# Altium schematic sheet RN487x Test Fixture v1.0.SchDoc
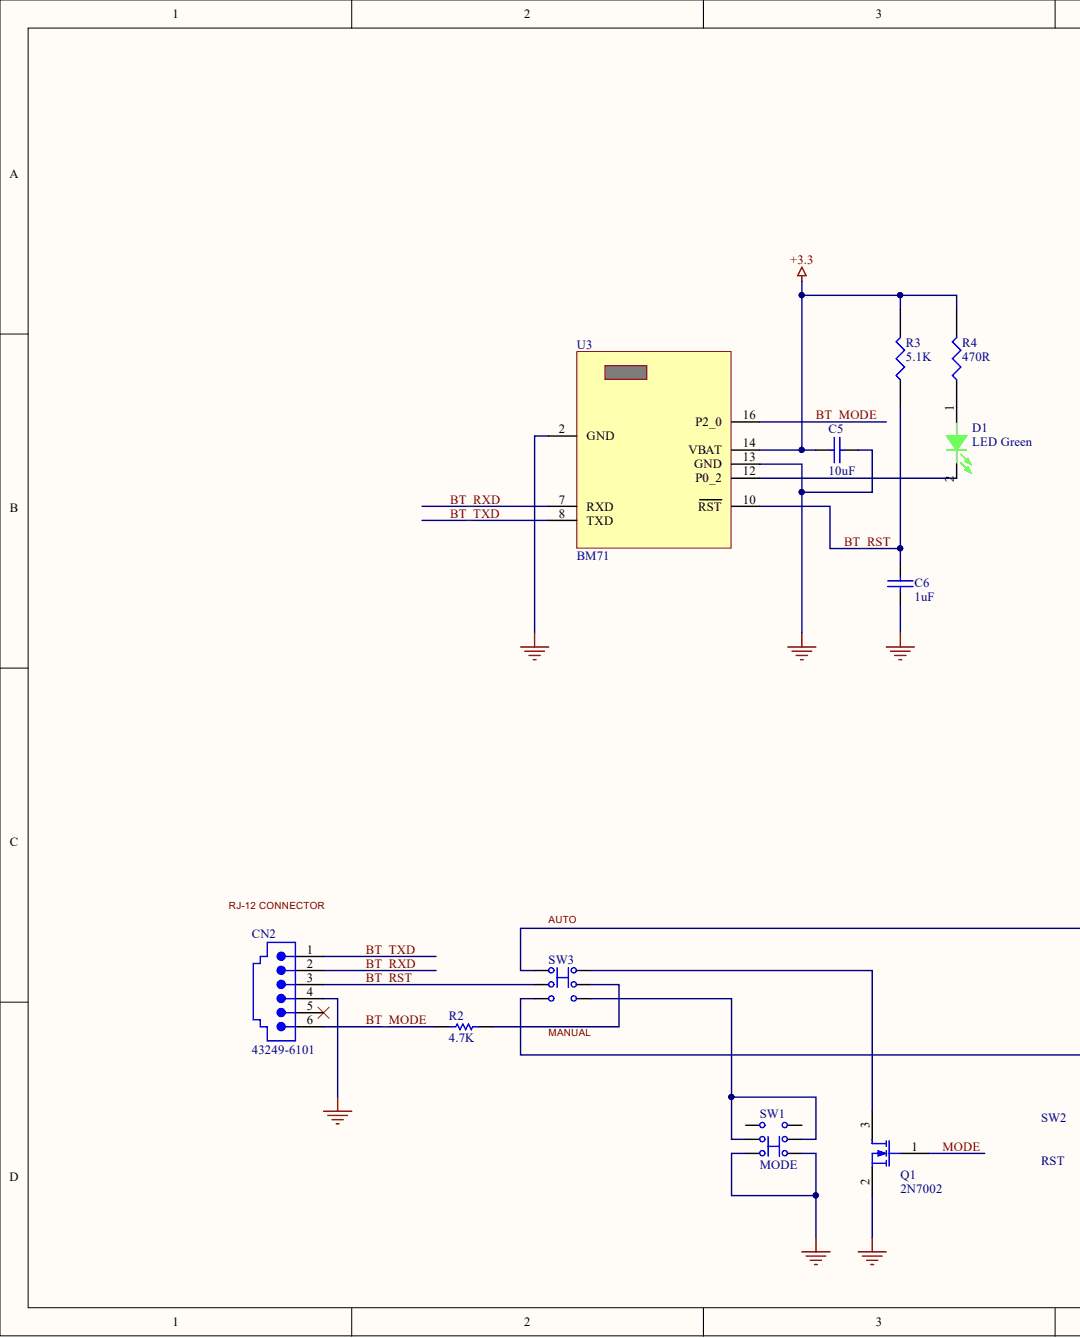
[diagram p0: RN487x Test Fixture v1.0.SchDoc, left]
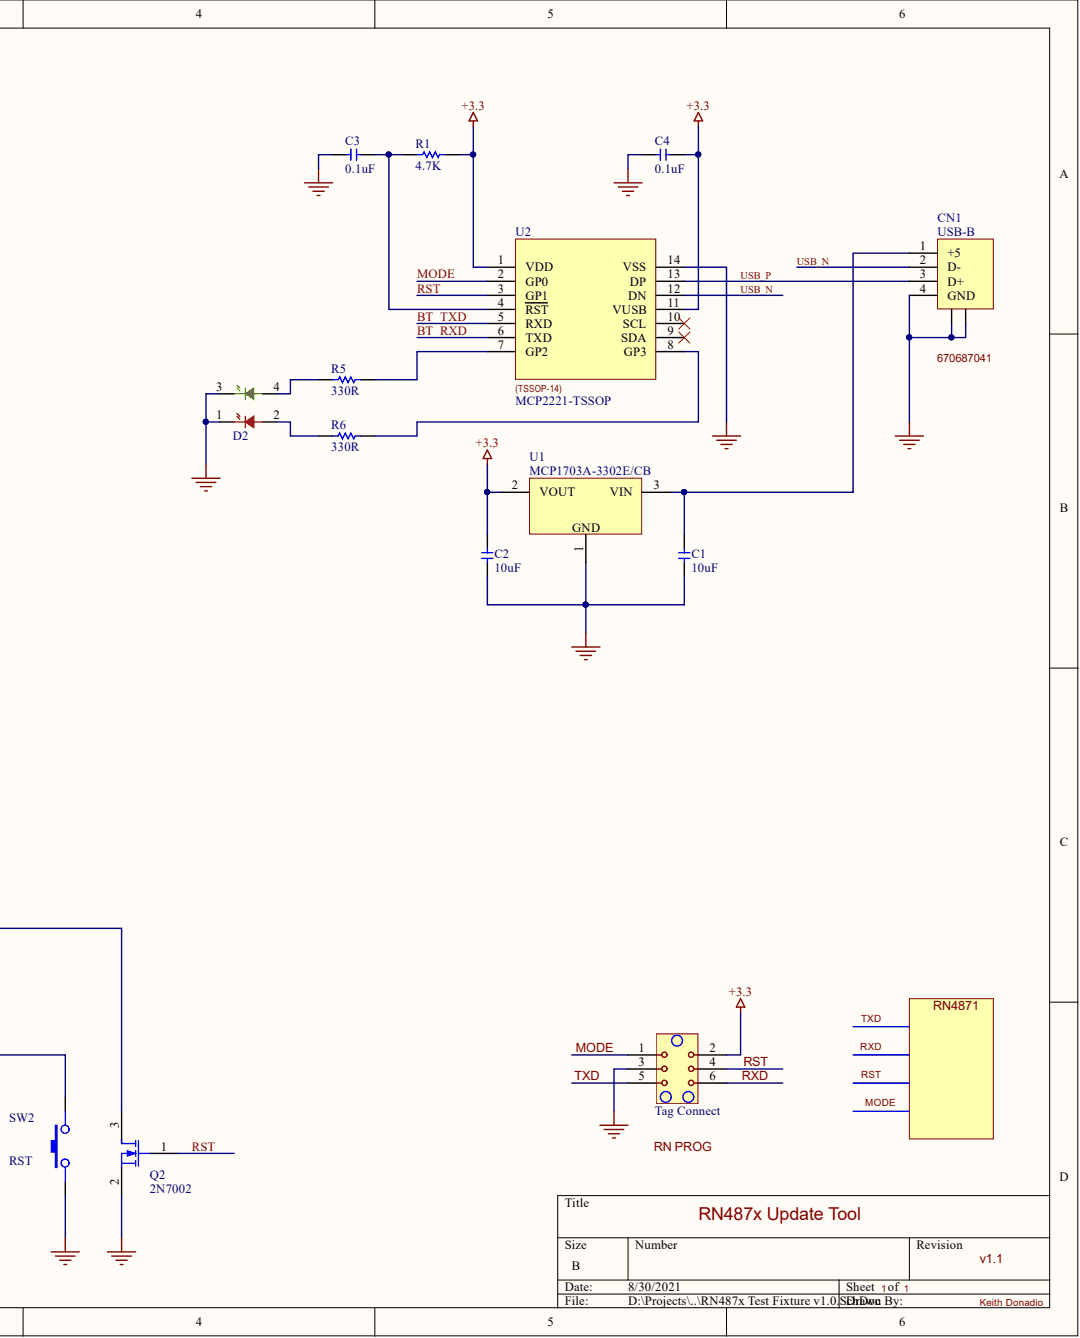
[diagram p1: RN487x Test Fixture v1.0.SchDoc, right]

## Parts
C1  10uF  [0603]
C2  10uF  [0603]
C3  0.1uF  [0603]
C4  0.1uF  [0603]
C5  10uF  [0603]
C6  1uF  [0603]
CN1  USB-B  [670687041]
CN2  43249-6101  [43249-6101]
D1  LED Green  [LTST-C190]
D2  LED_GR  [LTST-E682KRKGWT]  (value not drawn)
Q1  2N7002  [SOT-23]
Q2  2N7002  [SOT-23]
R1  4.7K  [0603]
R2  4.7K  [0603]
R3  5.1K  [0603]
R4  470R  [0603]
R5  330R  [0603]
R6  330R  [0603]
SW1  MODE  [MHSS1104]
SW2  RST  [PTS636-SK43-SMTR-LFS]
SW3  MAN/AUTO  [MHSS1104]  (value not drawn)
U1  MCP1703A-3302E/CB  [SOT-23]
U2  MCP2221-TSSOP  [TSSOP-14]
U3  BM71  [RN4871_POGO_FIXTURE]
  Tag Connect

## Nets
+3.3: C2.2 C4.1 C5.1 R1.2 R3.2 R4.2 U1.2 U2.1(VDD) U2.11(VUSB) U3.14(VBAT) .2
GND: C1.2 C2.1 C3.2 C4.2 C5.2 C6.2 CN1.4(GND) CN1.5 CN1.6 CN2.4 D2.1 D2.3 Q1.2 Q2.2 SW1.1 SW1.4 SW2.1 U1.1 U2.14(VSS) U3.2(GND) U3.13(GND) .3
Net_1: .1
Net_4: .4
Net_5: .5
Net_6: .6
BT_MODE: CN2.6 R2.2 U3.16(P2_0)
BT_RST: C6.1 CN2.3 R3.1 SW3.2 U3.10(RST)
BT_RXD: CN2.2 U2.6(TXD) U3.7(RXD)
BT_TXD: CN2.1 U2.5(RXD) U3.8(TXD)
MODE: Q1.1 U2.2(GP0)
RST: Q2.1 U2.3(GP1)
USB_N: CN1.2(D-) U2.12(DN)
USB_P: CN1.3(D+) U2.13(DP)
NetC1_1: C1.1 CN1.1(+5) U1.3
NetC3_1: C3.1 R1.1 U2.4(RST)
NetD1_1: D1.1 R4.1
NetD1_2: D1.2 U3.12(P0_2)
NetD2_2: D2.2 R6.1
NetD2_4: D2.4 R5.1
NetQ1_3: Q1.3 SW3.4
NetQ2_3: Q2.3 SW3.1
NetR2_1: R2.1 SW3.5
NetR5_2: R5.2 U2.7(GP2)
NetR6_2: R6.2 U2.8(GP3)
NetSW1_2: SW1.2 SW1.5 SW3.6
NetSW2_2: SW2.2 SW3.3

Not connected: CN2 5; SW1 3 6; U2 9(SDA) 10(SCL)

## Library data of the parts
C1-C4 = (no symbol name): Capacitor; Type: Ceramic; Category: Capacitor; Component Kind: Standard; ComponentLink1Description: Datasheet; Operating Temperature: -55C to +125C; Quantity Override: 1; Revision: 0
  C1 C2: Library Name: iHub WINC1500.SCHLIB
  C3 C4: Library Name: BM70 Click SCH.SchLib
C5 C6 = CAP: Capacitor; Component Kind: Standard; Library Name: Alternator .SCHLIB
CN1 = 670687041: USB B Connector; Component Kind: Standard; Library Name: RN to USB v1.0.SCHLIB
CN2 = 43249-6101: Right Angle, Low Profile, Flangeless, Unshielded PCB Jack; DrillSize: Nominal, Rounded to nearest 0.05mm; PCB_Layout: Complies with Manufacturer's Recommendation.; PCB_Mounting: Thru-Hole; PerformanceCategory: 3/4; Ports: 1; Positions/Loaded: Port1 - 6/6; Component Kind: Standard; ComponentLink1Description: Manufacturer Link; ComponentLink1URL: http://www.molex.com/; ComponentLink2Description: Datasheet; ComponentLink2URL: http://www.molex.com/cmc_upload/common_files/1212003_43249catalogpage.pdf; DatasheetDocument: 18-Dec-2001; PackageDocument: 18-Dec-2001; PackageReference: 43249-6101; Published: 25-Jun-2002; Signal Integrity: Modular Jack; Library Name: Molex Modular Jacks Right Angle.SchLib; Publisher: Altium Limited
D1 = (no symbol name): Green LED; Manufacturer 1: Lumex; Manufacturer Part Number 1: SML-LXT0805IW-TR; Description_: DIO LED RED 2V 30mA 0805; Forward Current: 30mA; MCHP-ID: LED1020; Owner: Stephen Humberd; Populated: YES; Power: 50mW; Reverse Current: 100uA; Reverse Voltage: 5V; Type: LED Single; Value: GRN; Category: Diode; Component Kind: Standard; ComponentLink1Description: Datasheet; ComponentLink1URL: https://chn-vm-apps.microchip.com/mchprepo/parts/Datasheets/SML-LXT0805IW-TR.pdf; Operating Temperature: -25C to +80C; Package: 0805; Quantity Override: 1; Revision: A; Status: Design; Supplier 1: Digi-Key; Supplier Part Number 1: 67-1552-1-ND; Library Name: Alternator .SCHLIB
D2 = (no symbol name): Green/Red LED; Manufacturer 1: Lumex; Manufacturer Part Number 1: SML-LXT0805IW-TR; Description_: DIO LED RED 2V 30mA 0805; Forward Current: 30mA; MCHP-ID: LED1020; Owner: Stephen Humberd; Populated: YES; Power: 50mW; Reverse Current: 100uA; Reverse Voltage: 5V; Type: LED Single; Value: GRN; Category: Diode; Component Kind: Standard; ComponentLink1Description: Datasheet; ComponentLink1URL: https://chn-vm-apps.microchip.com/mchprepo/parts/Datasheets/SML-LXT0805IW-TR.pdf; Operating Temperature: -25C to +80C; Package: 0805; Quantity Override: 1; Revision: A; Status: Design; Supplier 1: Digi-Key; Supplier Part Number 1: 67-1552-1-ND; Library Name: RN Fixture v1.0.SCHLIB
Q1 Q2 = 2N7002: N-Channel MOSFET; Component Kind: Standard; LatestRevisionDate: 17-Jul-2002; LatestRevisionNote: Re-released for DXP Platform.; Library Name: RN to USB v1.0.SCHLIB; PackageDescription: TO, Flat Index; 3 In-Line, Axial Leads; Body Dia. 4.6mm; Lead Dia. 0.5 mm (max); PackageReference: E3; PackageVersion: 1995/1996; Published: 8-Jun-2000; Publisher: Altium Limited
R1 = Res1: Resistor; Value: 1K; Component Kind: Standard; LatestRevisionDate: 17-Jul-2002; LatestRevisionNote: Re-released for DXP Platform.; Library Name: SCH Lib Rev 1.0.SchLib; PackageDescription: Axial Device, Thru-Hole; 2 Leads; 0.3 in Pin Spacing; PackageReference: AXIAL-0.3; Published: 8-Jun-2000; Publisher: Altium Limited
R2 R5 R6 = Res1: Resistor; Component Kind: Standard; LatestRevisionDate: 17-Jul-2002; LatestRevisionNote: Re-released for DXP Platform.; PackageDescription: Axial Device, Thru-Hole; 2 Leads; 0.3 in Pin Spacing; PackageReference: AXIAL-0.3; Published: 8-Jun-2000; Publisher: Altium Limited
  R2: Library Name: BM70 Click SCH.SchLib
  R5 R6: Library Name: RN Fixture v1.0.SCHLIB
R3 R4 = RES1: Component Kind: Standard; Library Name: CamWash v1.0 SCH.SchLib
SW1 SW3 = MHSS1104: Slide Switch, DPDT; Code_IPC: SOT26A-6; Code_JEITA: SC-74; Component Kind: Standard; LatestRevisionDate: 29-May-2009; LatestRevisionNote: IPC-7351 Footprint Added.; Library Name: RN to USB v1.0.SCHLIB; PackageDescription: 6-Pin SOT-23 Package 0.95 mm Pitch, 2.7 mm Lead Span; PackageReference: SOT23-6; PackageVersion: Mar-2001; Published: 24-Mar-1999; Publisher: Altium Limited
SW2 = PTS636-SK43-SMTR-LFS: Tactile Switch, SMT; Component Kind: Standard; Library Name: RN to USB v1.0.SCHLIB
U1 = MCP1703A: Voltage Regulator; Component Kind: Standard; LatestRevisionDate: 29-May-2009; LatestRevisionNote: IPC-7351 Footprint Added.; Library Name: RN to USB v1.0.SCHLIB; PackageDescription: 3-Pin Transistor Outline Package 2.54 mm Pitch, 15.1 mm Lead Span; PackageReference: D2PAK; Published: 24-Mar-1999; Publisher: Altium Limited
U2 = MCP2221_TSSOP: USB to UART Bridge; Component Kind: Standard; Library Name: BM71 USB Stick v1.0C.SCHLIB
U3 = RN4871_TEST_FIXTURE: BLE Module Test Fixture; Component Kind: Standard; LatestRevisionDate: 17-Jul-2002; LatestRevisionNote: Re-released for DXP Platform.; Library Name: RN Fixture v1.0.SCHLIB; PackageDescription: Bridge Rectifier; 4 Terminals; Body 16.8 x 16.8 mm (typ); Pitch 10.67 mm; PackageReference: TSOP-5; Published: 24-Mar-1999; Publisher: Altium Limited
 = TAG_CONN: ICSP Programming Connector; Component Kind: Standard; Library Name: Magic Eye v1.0 SCH.SchLib
